# Altium schematic sheet kill-switches_schematic.SchDoc(kill-switches_schematic)
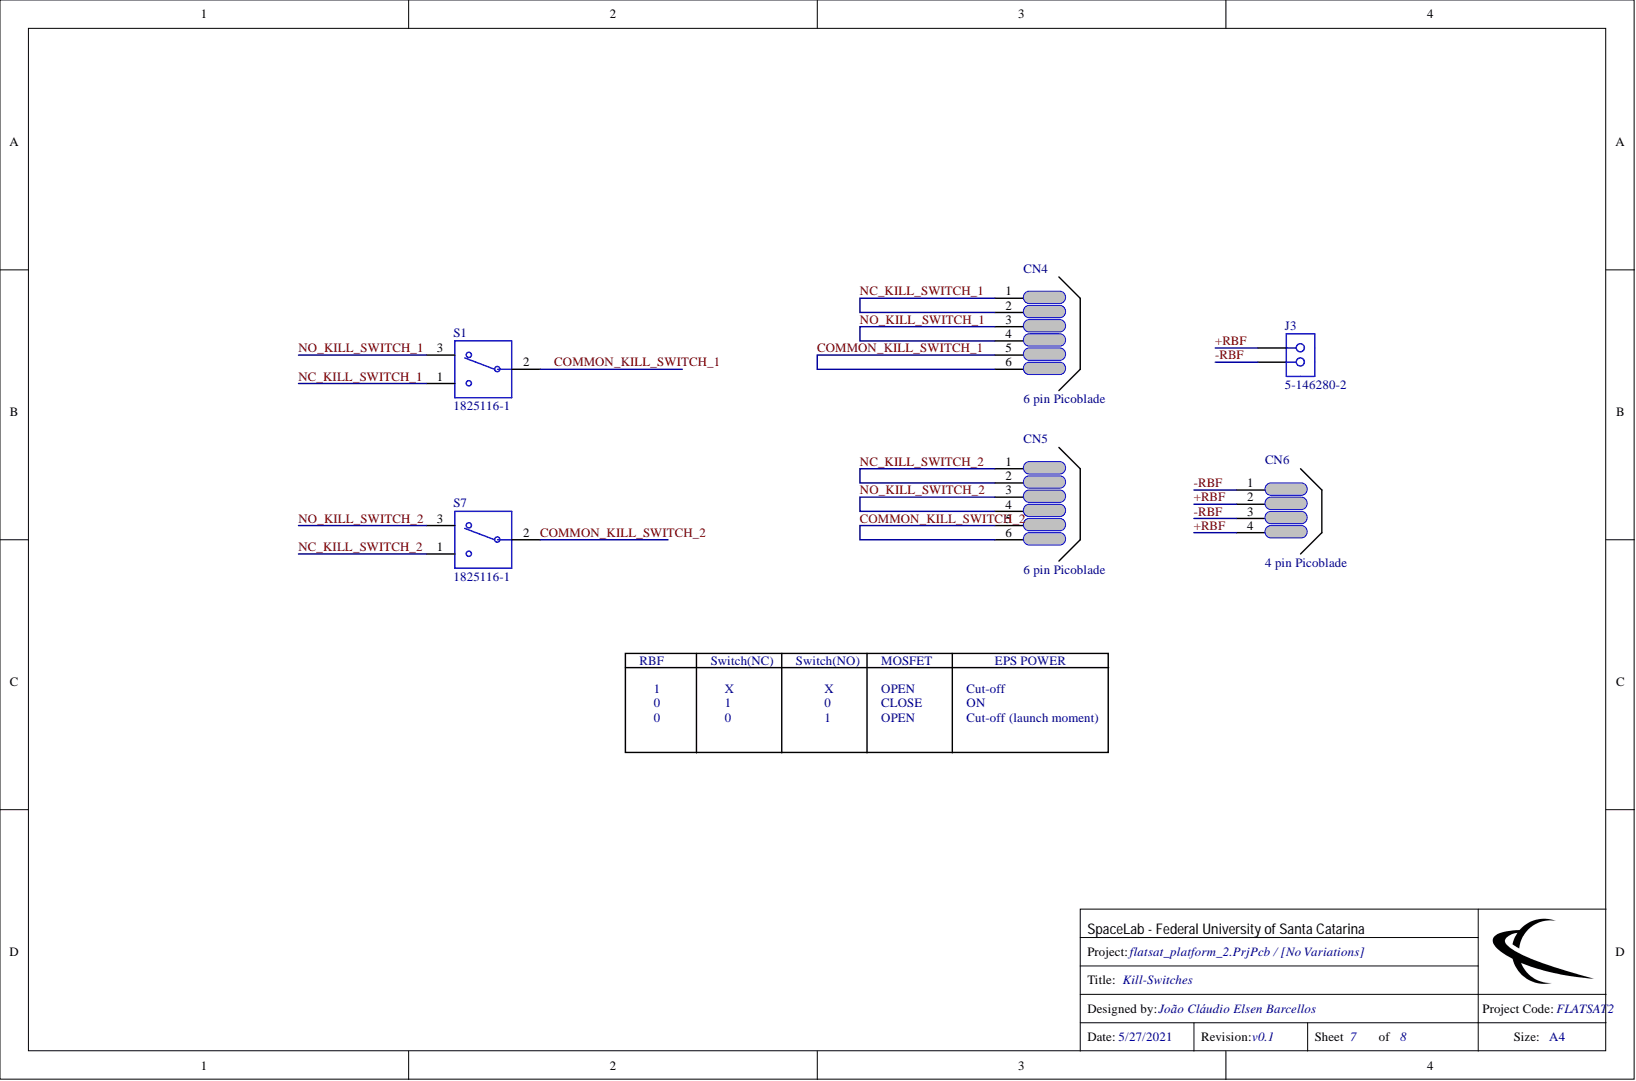
[diagram p0: kill-switches_schematic.SchDoc]

## Parts
CN4  6 pin Picoblade  [PICO BLADE 0533980671]
CN5  6 pin Picoblade  [PICO BLADE 0533980671]
CN6  4 pin Picoblade  [PICO BLADE 0533980471]
J3  5-146280-2  [PIN BAR 2 2.54mm 180 GRAUS]
S1  1825116-1  [SW_1825116-1]
S7  1825116-1  [SW_1825116-1]

## Nets
+RBF: CN6.2 CN6.4 J3.1
-RBF: CN6.1 CN6.3 J3.2
COMMON_KILL_SWITCH_1: CN4.5 CN4.6 S1.2
COMMON_KILL_SWITCH_2: CN5.5 CN5.6 S7.2
NC_KILL_SWITCH_1: CN4.1 CN4.2 S1.1
NC_KILL_SWITCH_2: CN5.1 CN5.2 S7.1
NO_KILL_SWITCH_1: CN4.3 CN4.4 S1.3
NO_KILL_SWITCH_2: CN5.3 CN5.4 S7.3

## Library data of the parts
CN4 CN5 = 53398-0671: 1.25mm Pitch PicoBlade™ Header, Surface Mount, Vertical, 6 Circuits; Manufacturer: Molex; Working Voltage: 125V; Number of Positions: 6; Insulation Resistance: 100MR; Glow Wire Compliant: Non-compliant; Gender: Male; Current Rating: 1A; Contact Resistance: 20mR; Body Plating: Tin; Voltage Rating (DC): 125V; Voltage Rating (AC): 125V; Voltage Rating: 125V; Termination: Solder; Supplier Part Number 2: 538-53398-0671; Supplier Part Number 1: WM7610DKR-ND; Supplier 2: Mouser; Supplier 1: Digi-Key; Stock 2: 26; Stock 1: 21086; REACH SVHC: Unknown; Radiation Hardening: No; Pricing 2: 1=1.15, 10=1.01, 100=0.864, 500=0.727, 1000=0.427, 2000=0.374 (USD); Pricing 1: 1=1.39, 10=1.26, 100=1.0802, 500=0.90014 (USD); Pitch: 1.25mm; Packaging: Tape and Reel; Package Quantity: 1000; Orientation: Vertical; Number of Rows: 1; Number of Contacts: 6; Number of Circuits: 6; Mount: Surface Mount; Min Operating Temperature: -40°C; Max Operating Temperature: 85°C; Manufacturer Part Number: 53398-0671; Lead Pitch: 1.25mm; Lead Free: Lead Free; Housing Material: Nylon; Housing Color: Natural; Flammability Rating: UL94 V-0; Contact Plating: Tin; Contact Material: Bronze,Tin; ComponentLink1URL: https://www.molex.com/pdm_docs/sd/533980671_sd.pdf?src-supplier=Digi-Key; ComponentLink1Description: https://www.molex.com/pdm_docs/sd/533980671_sd.pdf?src-supplier=Digi-Key; Component Kind: Standard; Color: Natural; Library Name: flatsat_platform_2.SchLib
CN6 = 0533980471: 1.25mm Pitch PicoBlade™ Header, Surface Mount, Vertical, 4 Circuits; Manufacturer Part Number: 53398-0471; Manufacturer: Molex; Working Voltage: 125V; Number of Positions: 4; Insulation Resistance: 100MR; Glow Wire Compliant: Non-compliant; Gender: Male; Current Rating: 1A; Contact Resistance: 20mR; Body Plating: Tin; Voltage Rating (DC): 125V; Voltage Rating (AC): 125V; Voltage Rating: 125V; Value: 0533980471; Termination: Solder; Supplier Part Number 2: 538-53398-0471; Supplier Part Number 1: WM7608CT-ND; Supplier 2: Mouser; Supplier 1: Digi-Key; Stock 2: 43680; Stock 1: 21236; REACH SVHC: Unknown; Radiation Hardening: No; Pricing 2: 1=0.98, 10=0.832, 100=0.716, 500=0.6, 1000=0.391, 2000=0.342 (USD); Pricing 1: 1=1.19, 10=1.044, 100=0.901, 500=0.73716 (USD); Pitch: 1.25mm; Packaging: Tape and Reel; Package Quantity: 1000; Orientation: Vertical; Number of Rows: 1; Number of Contacts: 4; Number of Circuits: 4; N of Positions: 4; Mount Type: SMD; Mount: Surface Mount; Min Operating Temperature: -40°C; Max Operating Temperature: 85°C; Lead Pitch: 1.25mm; Lead Free: Lead Free; Housing Material: Nylon; Housing Color: Natural; Flammability Rating: UL94 V-0; Contact Plating: Tin; Contact Material: Bronze,Tin,Brass; ComponentLink10URL: http://www.molex.com/webdocs/datasheets/pdf/en-us/0533980471_PCB_HEADERS.pdf?src-supplier=Avnet+Europe; ComponentLink10Description: http://www.molex.com/webdocs/datasheets/pdf/en-us/0533980471_PCB_HEADERS.pdf?src-supplier=Avnet+Europe; ComponentLink9URL: http://www.molex.com/webdocs/datasheets/pdf/en-us/0533980471_PCB_HEADERS.pdf?src-supplier=Avnet; ComponentLink9Description: http://www.molex.com/webdocs/datasheets/pdf/en-us/0533980471_PCB_HEADERS.pdf?src-supplier=Avnet; ComponentLink8URL: http://www.farnell.com/datasheets/2697809.pdf?src-supplier=Element14; ComponentLink8Description: http://www.farnell.com/datasheets/2697809.pdf?src-supplier=Element14; ComponentLink7URL: http://www.molex.com/webdocs/datasheets/pdf/en-us/0533980471_PCB_HEADERS.pdf?src-supplier=Farnell; ComponentLink7Description: http://www.molex.com/webdocs/datasheets/pdf/en-us/0533980471_PCB_HEADERS.pdf?src-supplier=Farnell; ComponentLink6URL: http://uk.rs-online.com/webdocs/13c4/0900766b813c4500.pdf?src-supplier=RSComponents; ComponentLink6Description: http://uk.rs-online.com/webdocs/13c4/0900766b813c4500.pdf?src-supplier=RSComponents; ComponentLink5URL: http://www.farnell.com/datasheets/1867381.pdf?src-supplier=Element14; ComponentLink5Description: http://www.farnell.com/datasheets/1867381.pdf?src-supplier=Element14; ComponentLink4URL: http://www.molex.com/webdocs/datasheets/pdf/en-us/0533980471_PCB_HEADERS.pdf?src-supplier=Element14; ComponentLink4Description: http://www.molex.com/webdocs/datasheets/pdf/en-us/0533980471_PCB_HEADERS.pdf?src-supplier=Element14; ComponentLink3URL: https://www.distrelec.biz/Web/Downloads/46/_e/gf116833-116846_e.pdf?mime=application%2Fpdf&src-supplier=Distrelec; ComponentLink3Description: https://www.distrelec.biz/Web/Downloads/46/_e/gf116833-116846_e.pdf?mime=application%2Fpdf&src-supplier=Distrelec; ComponentLink2URL: http://www.jameco.com/Jameco/Products/ProdDS/1932627.pdf?src-supplier=Jameco; ComponentLink2Description: http://www.jameco.com/Jameco/Products/ProdDS/1932627.pdf?src-supplier=Jameco; ComponentLink1URL: http://www.mouser.com/ds/2/276/0533980471_PCB_HEADERS-171069.pdf?src-supplier=Mouser; ComponentLink1Description: http://www.mouser.com/ds/2/276/0533980471_PCB_HEADERS-171069.pdf?src-supplier=Mouser; Component Kind: Standard; Color: Natural; Library Name: flatsat_platform_2.SchLib
J3 = 5-146280-2: 02 MODII HDR SRST B/A .100CL; Product_Type: Connector; Number_of_Positions: 2; MF: TE Connectivity; Centerline_Pitch: 2.54 mm[.1 in]; TE_purchase_URL: https://www.te.com/usa-en/product-5-146280-2.html?te_bu=Cor&te_type=disp&te_campaign=seda_glo_cor-seda-global-disp-prtnr-fy19-seda-model-bom-cta_sma-317_1&elqCampaignId=32493; SnapEDA_Link: https://www.snapeda.com/parts/5-146280-2/TE%20Connectivity%20AMP%20Connectors/view-part/298520/?ref=snap; Price: None; Package: None; Manufacturer Part Number: 5-146280-2; EU_RoHS_Compliance: Compliant; Component Kind: Standard; Availability: Unavailable; Library Name: flatsat_platform_2.SchLib
S1 S7 = 1825116-1: Slide Switch SPDT Through Hole, Right Angle; MF: TE Connectivity; TE_purchase_URL: https://www.te.com/usa-en/product-1825116-1.html?te_bu=Cor&te_type=disp&te_campaign=seda_glo_cor-seda-global-disp-prtnr-fy19-seda-model-bom-cta_sma-317_1&elqCampaignId=32493; SnapEDA_Link: https://www.snapeda.com/parts/1825116-1/TE%20Connectivity%20ALCOSWITCH%20Switches/view-part/497270/?ref=snap; Price: None; Package: None; Manufacturer Part Number: 1825116-1; EU_RoHS_Compliance: Compliant; Component Kind: Standard; Availability: Unavailable; Library Name: flatsat_platform_2.SchLib
